# Altium schematic sheet 03_MCU.SchDoc
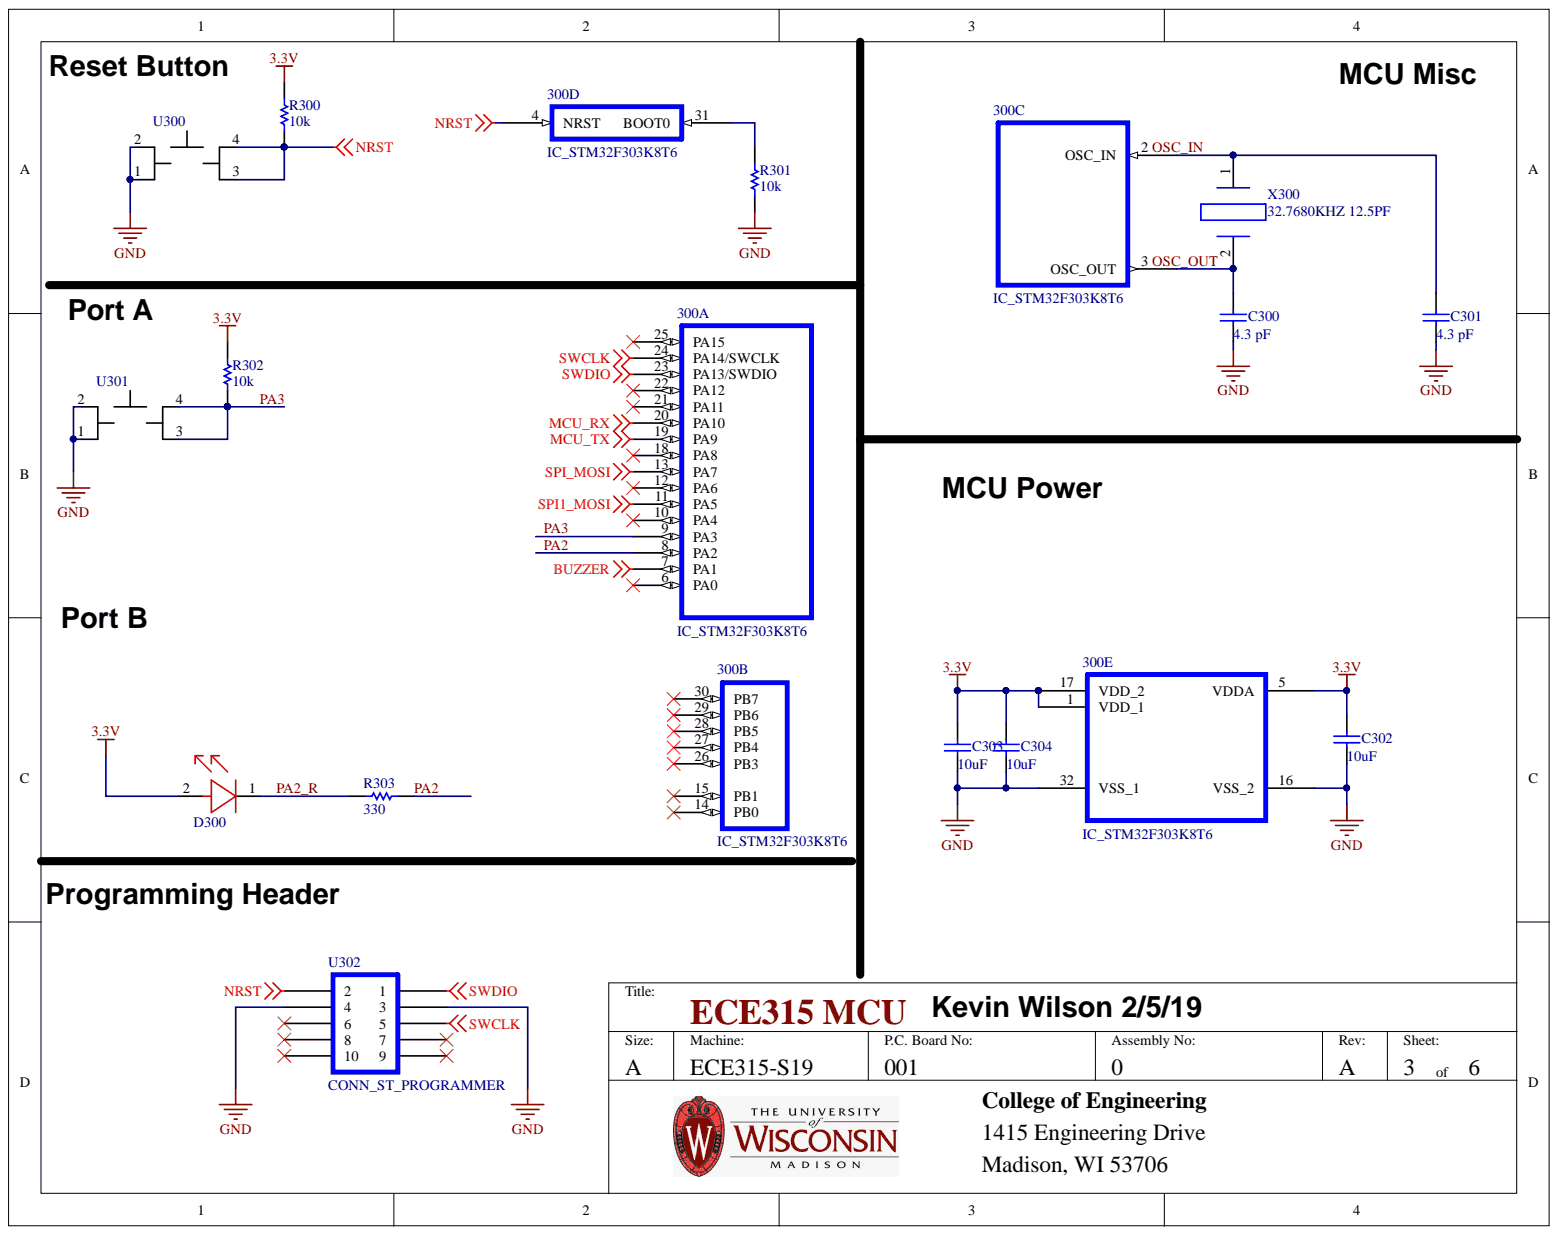
[diagram p0: 03_MCU.SchDoc]

## Parts
300  IC_STM32F303K8T6  (parts A,B,C,D,E)
C300  4.3 pF  [CAP_0805]
C301  4.3 pF  [CAP_0805]
C302  10uF  [CAP_0805]
C303  10uF  [CAP_0805]
C304  10uF  [CAP_0805]
D300  LED_RED_0805.1  [LED_RED_0805]  (value not drawn)
R300  10K  [RES_0805]
R301  10K  [RES_0805]
R302  10K  [RES_0805]
R303  330  [RES_0805]
U300  SWITCH_6mm_6mm_smd  [SW_6mm_6mm_SMT]  (value not drawn)
U301  SWITCH_6mm_6mm_smd  [SW_6mm_6mm_SMT]  (value not drawn)
U302  CONN_ST_PROGRAMMER  [CONN_ST_PROGRAMMER]
X300  32.7680KHZ 12.5PF  [NX3215SA-32.768K-STD-MUA-8]

## Nets
3.3V: 300.1(VDD_1) 300.5(VDDA) 300.17(VDD_2) C302.1 C303.1 C304.1 D300.2 R300.2 R302.2
BUZZER: 300.7(PA1)
GND: 300.16(VSS_2) 300.32(VSS_1) C300.1 C301.1 C302.2 C303.2 C304.2 R301.1 U300.1 U300.2 U301.1 U301.2 U302.3 U302.4
MCU_RX: 300.20(PA10)
MCU_TX: 300.19(PA9)
NRST: 300.4(NRST) R300.1 U300.3 U300.4 U302.2
SPI1_MOSI: 300.11(PA5)
SPI_MOSI: 300.13(PA7)
SWCLK: 300.24(PA14/SWCLK) U302.5
SWDIO: 300.23(PA13/SWDIO) U302.1
PA2: 300.8(PA2) R303.1
PA2_R: D300.1 R303.2
PA3: 300.9(PA3) R302.1 U301.3 U301.4
Net300_2: 300.2(OSC_IN) C301.2 X300.1
Net300_3: 300.3(OSC_OUT) C300.2 X300.2
Net300_31: 300.31(BOOT0) R301.2
OSC_IN:
OSC_OUT:

Not connected: 300 6(PA0) 10(PA4) 12(PA6) 14(PB0) 15(PB1) 18(PA8) 21(PA11) 22(PA12) 25(PA15) 26(PB3) 27(PB4) 28(PB5) 29(PB6) 30(PB7); U302 6 7 8 9 10

## Library data of the parts
C300 C301 = CAP_0805_P_004.30: CAP CER 4.3PF 100V NP0 0805; Manufacturer: Kyocera AVX; Manufacturer Part Number: 08051U4R3BAT2A; Dielectric: C0G; Capacitance: 4.3pF; Case/Package: 0805; Case Code (Imperial): 0805; Case Code (Metric): 2012; Component Kind: Standard; ComponentLink1Description: https://datasheet.ciiva.com/204/ccog-204944.pdf; ComponentLink1URL: https://datasheet.ciiva.com/204/ccog-204944.pdf; ComponentLink2Description: https://datasheet.ciiva.com/11570/ccog-11570969.pdf; ComponentLink2URL: https://datasheet.ciiva.com/11570/ccog-11570969.pdf; ComponentLink3Description: http://datasheets.avx.com/U-Series.pdf; ComponentLink3URL: http://datasheets.avx.com/U-Series.pdf; ComponentLink4Description: http://www.avxcorp.com/docs/Catalogs/useries.pdf; ComponentLink4URL: http://www.avxcorp.com/docs/Catalogs/useries.pdf; Composition: Ceramic; Depth: 1.25mm; Height: 1.02mm; Length: 2.01mm; Library Name: ece315.SCHLIB; Max Operating Temperature: 125°C; Min Operating Temperature: -55°C; Mount: Surface Mount; Package Quantity: 4000; Packaging: Tape and Reel; Pricing 1: 4000=0.14663 (USD); Radiation Hardening: No; Stock 1: 0; Supplier 1: Digi-Key; Termination: SMD/SMT; Thickness: 0.045inch; Voltage Rating: 100V; Voltage Rating (DC): 100V; Width: 1.25mm
C302-C304 = CAP_0805_U_010.00: CAP CER 10UF 16V X5R 0805; Manufacturer: Samsung; Manufacturer Part Number: CL21A106KOFNNNE; Tolerance: 10%; Capacitance: 10uF; Case/Package: 0805; Case Code (Imperial): 0805; Case Code (Metric): 2012; Component Kind: Standard; ComponentLink1Description: https://datasheet.ciiva.com/25730/cl21a106kpclqnc-25730594.pdf; ComponentLink1URL: https://datasheet.ciiva.com/25730/cl21a106kpclqnc-25730594.pdf; ComponentLink2Description: http://www.samsungsem.com/kr/support/product-search/mlcc/CL21A106KOFNNNE.jsp; ComponentLink2URL: http://www.samsungsem.com/kr/support/product-search/mlcc/CL21A106KOFNNNE.jsp; ComponentLink3Description: https://datasheet.ciiva.com/26920/getdatasheetpartid-355170-26920201.pdf; ComponentLink3URL: https://datasheet.ciiva.com/26920/getdatasheetpartid-355170-26920201.pdf; ComponentLink4Description: http://www1.futureelectronics.com/doc/SAMSUNG/CL21A106KOFNNNE.pdf; ComponentLink4URL: http://www1.futureelectronics.com/doc/SAMSUNG/CL21A106KOFNNNE.pdf; ComponentLink5Description: https://www.verical.com/server-webapp/html/getDatasheet?partID=354009; ComponentLink5URL: https://www.verical.com/server-webapp/html/getDatasheet?partID=354009; Depth: 1.25mm; Dielectric: X5R; Height: 1.25mm; Length: 2mm; Library Name: ece315.SCHLIB; Max Operating Temperature: 85°C; Min Operating Temperature: -55°C; Mount: Surface Mount; Package Quantity: 2000; Packaging: Tape and Reel; Pricing 1: 1=0.29, 10=0.199, 100=0.1009, 500=0.06904, 1000=0.06107 (USD); Radiation Hardening: No; Stock 1: 1158169; Supplier 1: Digi-Key; Thickness: 0.053inch; Voltage Rating: 16V; Width: 0.049inch
D300 = LED_RED_0805.1: LED SUPER RED CLR THIN 0805 SMD; Manufacturer: Vishay / Lite-On; Manufacturer Part Number: LTST-C171KRKT; Chip Material: AlGaInP; DELETED: Compliant; Dominant Wave Length: 631 nm; Forward Current: 30 mA; Forward Voltage: 2.4 V; High Brightness: Yes; Luminous Intensity: 54mcd; Main Category: Chip LED; Number of  Elements: 1; Peak Wavelength: 639 nm; Power Dissipation: 75 W; Product Depth (mm): 1.25 mm; Product Height (mm): 0.8 mm; Product Length (mm): 2 mm; Rad Hardened: No; Reverse Voltage: 5 V; Shape Type: Rectangular; Test Current (It): 20 mA; Type: Uni-Color; Viewing Angle: 130 deg; Component Kind: Standard; ComponentLink1Description: https://datasheet.ciiva.com/2110/s-110-ltst-c171krkt-2110066.pdf; ComponentLink1URL: https://datasheet.ciiva.com/2110/s-110-ltst-c171krkt-2110066.pdf; ComponentLink2Description: https://datasheet.ciiva.com/2110/s-110-ltst-c171krkt-2110067.pdf; ComponentLink2URL: https://datasheet.ciiva.com/2110/s-110-ltst-c171krkt-2110067.pdf; ComponentLink3Description: https://datasheet.ciiva.com/2110/s-110-ltst-c171krkt-2110068.pdf; ComponentLink3URL: https://datasheet.ciiva.com/2110/s-110-ltst-c171krkt-2110068.pdf; ComponentLink4Description: https://datasheet.ciiva.com/12403/lite-on-ltst-c171krkt-187201-12403781.pdf; ComponentLink4URL: https://datasheet.ciiva.com/12403/lite-on-ltst-c171krkt-187201-12403781.pdf; ComponentLink5Description: https://datasheet.ciiva.com/11775/0900766b80dc0299-11775643.pdf; ComponentLink5URL: https://datasheet.ciiva.com/11775/0900766b80dc0299-11775643.pdf; ComponentLink6Description: https://datasheet.ciiva.com/16444/lite-on20ltst-c171krkt-16444779.pdf; ComponentLink6URL: https://datasheet.ciiva.com/16444/lite-on20ltst-c171krkt-16444779.pdf; Emitting Color: Red; Lens Dimensions: 1.4x1.25x0.5 mm; Library Name: ece315.SCHLIB; Lum Intensity: 54mcd; Mounting: Surface Mount; Operating Temperature Classification: Industrial; Operating Temp Range: -55C to 85C; Package Type: Chip Led; Packaging: Tape and Reel; Pin Count: 2; Pricing 1: 1=0.31, 10=0.225, 25=0.1656, 100=0.1183, 250=0.0804, 500=0.071, 1000=0.0556 (USD); Stock 1: 97347; Supplier 1: Digikey; Supplier Part Number 1: 160-1427-1-ND
R300-R302 = RES_0805_K_010.00: RES SMD 10K OHM 1% 1/8W 0805; Manufacturer: Panasonic / Panasonic ECG; Manufacturer Part Number: ERJ-6ENF1002V; Max Power Dissipation: 125mW; No. of Pins: 2; Product Range: PANASONIC - ERJ Series; Resistor Case Style: 0805; Resistor Element Material: Thick Film; Temperature Coefficient: ± 200ppm/°C; Voltage Rating: 150V; Case/Package: 0805; Case Code (Imperial): 0805; Case Code (Metric): 2012; Component Kind: Standard; ComponentLink1Description: https://datasheet.ciiva.com/11808/0900766b80f80784-11808080.pdf; ComponentLink1URL: https://datasheet.ciiva.com/11808/0900766b80f80784-11808080.pdf; ComponentLink2Description: https://datasheet.ciiva.com/16514/aoa0000ce2-16514021.pdf; ComponentLink2URL: https://datasheet.ciiva.com/16514/aoa0000ce2-16514021.pdf; ComponentLink3Description: https://datasheet.ciiva.com/27055/1988971-27055733.pdf; ComponentLink3URL: https://datasheet.ciiva.com/27055/1988971-27055733.pdf; ComponentLink4Description: https://datasheet.ciiva.com/16759/70260748-16759350.pdf; ComponentLink4URL: https://datasheet.ciiva.com/16759/70260748-16759350.pdf; ComponentLink5Description: https://datasheet.ciiva.com/16725/70260748-16725031.pdf; ComponentLink5URL: https://datasheet.ciiva.com/16725/70260748-16725031.pdf; ComponentLink6Description: https://datasheet.ciiva.com/7830/426256-7830745.pdf; ComponentLink6URL: https://datasheet.ciiva.com/7830/426256-7830745.pdf; ComponentLink7Description: https://datasheet.ciiva.com/46372/1678782-46372714.pdf; ComponentLink7URL: https://datasheet.ciiva.com/46372/1678782-46372714.pdf; ComponentLink8Description: https://datasheet.ciiva.com/46372/1678782-46372715.pdf; ComponentLink8URL: https://datasheet.ciiva.com/46372/1678782-46372715.pdf; ComponentLink9Description: https://datasheet.ciiva.com/17212/aoa0000ce2-17212108.pdf; ComponentLink9URL: https://datasheet.ciiva.com/17212/aoa0000ce2-17212108.pdf; ComponentLink10Description: https://datasheet.ciiva.com/30140/aoa0000ce2-30140236.pdf; ComponentLink10URL: https://datasheet.ciiva.com/30140/aoa0000ce2-30140236.pdf; ComponentLink11Description: https://datasheet.ciiva.com/16740/aoa0000ce2-16740669.pdf; ComponentLink11URL: https://datasheet.ciiva.com/16740/aoa0000ce2-16740669.pdf; ComponentLink12Description: https://datasheet.ciiva.com/24070/aoa0000ce2-24070702.pdf; ComponentLink12URL: https://datasheet.ciiva.com/24070/aoa0000ce2-24070702.pdf; ComponentLink13Description: http://www.yageo.com/documents/recent/PYu-RC_Group_51_RoHS_L_9.pdf; ComponentLink13URL: http://www.yageo.com/documents/recent/PYu-RC_Group_51_RoHS_L_9.pdf; ComponentLink14Description: http://www.farnell.com/datasheets/444164.pdf; ComponentLink14URL: http://www.farnell.com/datasheets/444164.pdf; ComponentLink15Description: http://www.yageo.com/documents/recent/PYu-RC_Group_51_RoHS_L_10.pdf; ComponentLink15URL: http://www.yageo.com/documents/recent/PYu-RC_Group_51_RoHS_L_10.pdf; ComponentLink16Description: http://www.yageo.ru/pdf/RC0805.pdf; ComponentLink16URL: http://www.yageo.ru/pdf/RC0805.pdf; ComponentLink17Description: http://www.yageo.com/NewPortal/yageodocoutput?fileName=/pdf/R-Chip/PYu-AC_51_RoHS_L_6.pdf; ComponentLink17URL: http://www.yageo.com/NewPortal/yageodocoutput?fileName=/pdf/R-Chip/PYu-AC_51_RoHS_L_6.pdf; Composition: Thick Film; Depth: 1.25mm; Features: Moisture Resistant; Height: 0.024inch; Lead Free: Lead Free; Length: 2mm; Library Name: ece315.SCHLIB; Max Operating Temperature: 155°C; Military Standard: Not; Min Operating Temperature: -55°C; Number of Terminations: 2; Package Quantity: 1; Packaging: Tape and Reel; Power Rating: 125mW; Pricing 1: 1=0.1, 10=0.05, 100=0.0205, 1000=0.00921, 2500=0.00799 (USD); REACH SVHC: Unknown; Resistance: 10k; Resistance Tolerance: ± 1%; Resistor Element Type: Thick Film; Resistor Mounting: SMD; Series: ERJ; Stock 1: 802268; Supplier 1: Digi-Key; Termination: SMD/SMT; Tolerance: 1%; Width: 0.049inch
R303 = RES_0805_R_330.00: RES SMD 330 OHM 1% 1/8W 0805; Manufacturer: Panasonic / Panasonic ECG; Manufacturer Part Number: ERJ-6ENF3300V; Max Power Dissipation: 125mW; Product Range: PANASONIC - ERJ Series; Resistor Case Style: 0805 ^2012 Metric]; Resistor Element Type: Thick Film; SVHC: To Be Advised; Temperature Coefficient: ± 200ppm/°C; Voltage Rating: 150V; Case/Package: 0805; Case Code (Imperial): 0805; Case Code (Metric): 2012; Component Kind: Standard; ComponentLink1Description: https://datasheet.ciiva.com/11821/0900766b80f80784-11821635.pdf; ComponentLink1URL: https://datasheet.ciiva.com/11821/0900766b80f80784-11821635.pdf; ComponentLink2Description: https://datasheet.ciiva.com/30131/aoa0000ce2-30131349.pdf; ComponentLink2URL: https://datasheet.ciiva.com/30131/aoa0000ce2-30131349.pdf; ComponentLink3Description: https://datasheet.ciiva.com/24025/aoa0000ce2-24025991.pdf; ComponentLink3URL: https://datasheet.ciiva.com/24025/aoa0000ce2-24025991.pdf; ComponentLink4Description: https://datasheet.ciiva.com/17206/aoa0000ce2-17206152.pdf; ComponentLink4URL: https://datasheet.ciiva.com/17206/aoa0000ce2-17206152.pdf; ComponentLink5Description: https://datasheet.ciiva.com/46436/1678782-46436633.pdf; ComponentLink5URL: https://datasheet.ciiva.com/46436/1678782-46436633.pdf; ComponentLink6Description: https://datasheet.ciiva.com/46436/1678782-46436634.pdf; ComponentLink6URL: https://datasheet.ciiva.com/46436/1678782-46436634.pdf; ComponentLink7Description: https://datasheet.ciiva.com/16760/70260748-16760668.pdf; ComponentLink7URL: https://datasheet.ciiva.com/16760/70260748-16760668.pdf; ComponentLink8Description: https://datasheet.ciiva.com/16780/70260748-16780764.pdf; ComponentLink8URL: https://datasheet.ciiva.com/16780/70260748-16780764.pdf; ComponentLink9Description: https://datasheet.ciiva.com/22684/1678782-22684798.pdf; ComponentLink9URL: https://datasheet.ciiva.com/22684/1678782-22684798.pdf; ComponentLink10Description: https://datasheet.ciiva.com/28590/1678782-28590783.pdf; ComponentLink10URL: https://datasheet.ciiva.com/28590/1678782-28590783.pdf; ComponentLink11Description: http://www.yageo.ru/pdf/RC0805.pdf; ComponentLink11URL: http://www.yageo.ru/pdf/RC0805.pdf; ComponentLink12Description: http://www.yageo.com/documents/recent/PYu-RC_Group_51_RoHS_L_9.pdf; ComponentLink12URL: http://www.yageo.com/documents/recent/PYu-RC_Group_51_RoHS_L_9.pdf; ComponentLink13Description: http://www.yageo.com/documents/recent/PYu-RC_Group_51_RoHS_L_10.pdf; ComponentLink13URL: http://www.yageo.com/documents/recent/PYu-RC_Group_51_RoHS_L_10.pdf; ComponentLink14Description: http://www.yageo.com.tw/exep/pages/download/literatures/PYu-R_INT-thick_7.pdf; ComponentLink14URL: http://www.yageo.com.tw/exep/pages/download/literatures/PYu-R_INT-thick_7.pdf; ComponentLink15Description: http://www.farnell.com/datasheets/444164.pdf; ComponentLink15URL: http://www.farnell.com/datasheets/444164.pdf; Composition: Thick Film; Depth: 1.25mm; Features: Moisture Resistant; Height: 0.024inch; Lead Free: Lead Free; Length: 2mm; Library Name: ece315.SCHLIB; Max Operating Temperature: 155°C; Military Standard: Not; Min Operating Temperature: -55°C; Number of Terminations: 2; Package Quantity: 1; Packaging: Full Reel; Power Rating: 125mW; Pricing 1: 1=0.1, 10=0.042, 100=0.017, 1000=0.00762, 2500=0.00662 (USD); REACH SVHC: Unknown; Resistance: 330; Resistance Tolerance: ± 1%; Stock 1: 3197096; Supplier 1: Digi-Key; Termination: SMD/SMT; Tolerance: 1%; Width: 0.049inch
U300 U301 = SWITCH_6mm_6mm_smd: SWITCH TACTILE SPST-NO 0.05A 12V; Manufacturer: C&K Components; Manufacturer Part Number: PTS645SH50SMTR92 LFS; Actuator Height off PCB, from Pins: 5.00mm; Actuator Material: Polyamide (PA66), Nylon 6/6; Actuator Orientation: Top Actuated; Actuator Type: Standard; Contact Rating @ Voltage: 0.05A @ 12VDC; Current Rating: 50mA; Illumination: Non-Illuminated; Mechanical Life: 100,000 Cycles; Operating Force: 200gf; Outline: 6.00mm x 6.00mm; Switch Function: Off-Mom; Throw Configuration: SPST; Category: Switches; Circuit: SPST-NO; Component Kind: Standard; ComponentLink1Description: http://www.mouser.com/ds/2/60/pts530_4mar13-305907.pdf; ComponentLink1URL: http://www.mouser.com/ds/2/60/pts530_4mar13-305907.pdf; ComponentLink2Description: http://www.ck-components.com/80182/pts530_4mar13.pdf/; ComponentLink2URL: http://www.ck-components.com/80182/pts530_4mar13.pdf/; Contact Plating: Silver; Features: -; Illumination Type: Non-Illuminated; Illumination Type, Color: -; Illumination Voltage (Nominal): -; Ingress Protection: -; Library Name: ece315.SCHLIB; Max Operating Temperature: 85°C; Min Operating Temperature: -40°C; Mount: Surface Mount; Mounting Type: Surface Mount; Operating Temperature: -20°C ~ 60°C; Package Quantity: 7000; Packaging: Tape & Reel (TR); Pricing 2: 1=0.24, 10=0.229, 50=0.213, 100=0.202, 1000=0.138, 10000=0.104 (USD); RoHS: RoHS Compliant; Stock 2: 36566; Supplier: Digi-Key; Supplier 1: Digi-Key; Supplier 2: Mouser; Supplier Part Number: CKN9085TR-ND; Supplier Part Number 1: CKN9085CT-ND; Switch Travel: -; Termination: Gull Wing; Termination Style: Gull Wing; Voltage Rating (DC): 12V
U302 = CONN_ST_PROGRAMMER: Manufacturer: Wurth Electronics; Connector Type: Header,Socket; Current Rating: 3A; Gender: Receptacle; Insulation Resistance: 1GR; Number of Contacts: 10; Pitch: 2.54mm; Row Spacing: 0.1inch; Voltage Rating: 250V; Color: Black; Component Kind: Standard; ComponentLink1Description: http://www.mouser.com/ds/2/445/6130xx21821-537816.pdf; ComponentLink1URL: http://www.mouser.com/ds/2/445/6130xx21821-537816.pdf; ComponentLink2Description: https://katalog.we-online.de/em/datasheet/6130xx21821.pdf; ComponentLink2URL: https://katalog.we-online.de/em/datasheet/6130xx21821.pdf; ComponentLink3Description: http://www.farnell.com/datasheets/1442176.pdf; ComponentLink3URL: http://www.farnell.com/datasheets/1442176.pdf; Contact Gender: Female; Contact Material: Copper,Copper Alloy; Contact Plating: Gold; Flammability Rating: UL94 V-0; Height: 12.5mm; Housing Material: PBT; Length: 5.08mm; Library Name: ece315.SCHLIB; Max Operating Temperature: 125°C; Min Operating Temperature: -40°C; Mount: Through Hole; Number of Positions: 10; Number of Rows: 2; Package Quantity: 320; Packaging: Tray; Pricing 1: 1=0.74, 10=0.728, 50=0.672, 100=0.616, 320=0.56 (USD); REACH SVHC: Unknown; Stock 1: 1798; Supplier 1: Digi-Key; Supplier Part Number 1: 732-2861-ND; Termination: Solder; Width: 13.2mm
X300 = CRYSTAL_NX3215SA-32.768K: CRYSTAL 32.768KHZ 12.5PF SMD; Manufacturer: Nihon Dempa Kogyo (NDK); Catalog Drawings: NX3215SA Series Top    NX3215SA Series Side    NX3215SA Series Bottom    NX3215SA Series Circuit; Dynamic Catalog: NX3215SA Series; ESR (Equivalent Series Resistance): 70 kOhm; Featured Product: NX3215SA Series 32.768KHz SMD Crystals; Frequency Tolerance: ±20ppm; Operating Mode: Fundamental; Other Names: 644-1156-6; Category: Crystals and Oscillators; Component Kind: Standard; ComponentLink1Description: https://datasheet.ciiva.com/857/c-nx3215sa-std-mua-9-e-857050.pdf; ComponentLink1URL: https://datasheet.ciiva.com/857/c-nx3215sa-std-mua-9-e-857050.pdf; ComponentLink2Description: https://datasheet.ciiva.com/857/c-nx3215sa-std-mua-9-e-857051.pdf; ComponentLink2URL: https://datasheet.ciiva.com/857/c-nx3215sa-std-mua-9-e-857051.pdf; ComponentLink3Description: https://datasheet.ciiva.com/857/c-nx3215sa-std-mua-9-e-857052.pdf; ComponentLink3URL: https://datasheet.ciiva.com/857/c-nx3215sa-std-mua-9-e-857052.pdf; Family: Crystals; Frequency: 32.768kHz; Height: 0.035" (0.90mm); Library Name: ece315.SCHLIB; Load Capacitance: 12.5pF; Manufacturer Part Number: NX3215SA-32.768K-STD-MUA-8; Mounting Type: Surface Mount; Operating Temperature: -40°C ~ 85°C; Package / Case: 2-SMD; Packaging: Digi-Reel®; Pricing 1: 1=0.94, 10=0.835, 50=0.7804, 100=0.6897, 500=0.6534, 1000=0.5445 (USD); Series: NX3215SA; Size / Dimension: 0.126" L x 0.059" W (3.20mm x 1.50mm); Standard Package: 1; Stock 1: 45314; Supplier 1: Digikey; Supplier Part Number 1: 644-1156-1-ND; Type: kHz Crystal (Tuning Fork)
300 = IC_STM32F303K8T6: Component Kind: Standard; Library Name: ece315.SCHLIB
